# Altium schematic sheet Э3("All Documents",Physical)
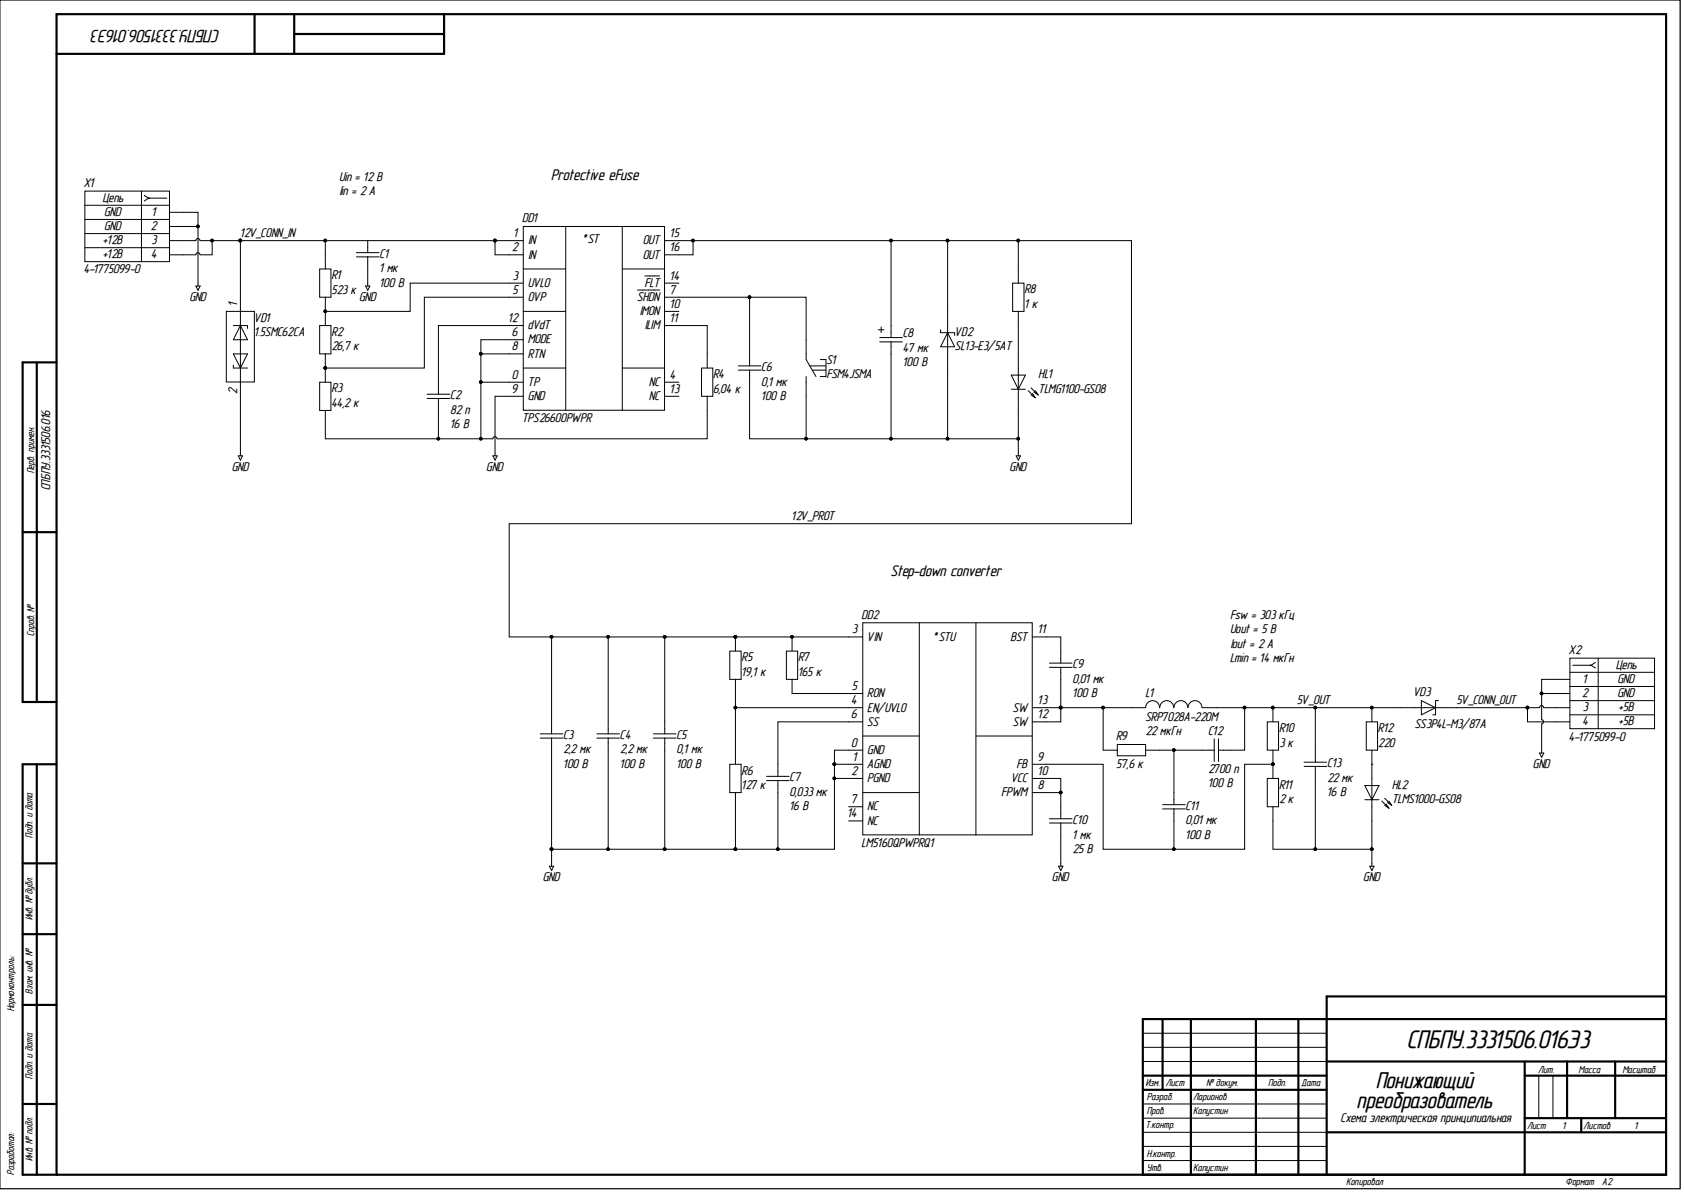
[diagram p0: Э3("All Documents",Physical)]

## Parts
C1  1 мк  Номинальное напряжение: 100 В  [C1206]
C2  82 п  Номинальное напряжение: 16 В  [C0603]
C3  2,2 мк  [C1206]
C4  2,2 мк  Номинальное напряжение: 100 В  [C1206]
C5  0,1 мк  Номинальное напряжение: 100 В  [C0603]
C6  0,1 мк  [C0603]
C7  0,033 мк  Номинальное напряжение: 16 В  [C0603]
C8  47 мк  [CAPAE-1050X1050X1250]
C9  0,01 мк  Номинальное напряжение: 100 В  [C0603]
C10  1 мк  Номинальное напряжение: 25 В  [C0603]
C11  0,01 мк  Номинальное напряжение: 100 В  [C0603]
C12  2700 п  Номинальное напряжение: 100 В  [C0603]
C13  22 мк  Номинальное напряжение: 16 В  [C1206]
DD1  TPS26600PWPR  [HTSSOP-16]
DD2  LM5160QPWPRQ1  [HTSSOP-14]
HL1  TLMG1100-GS08  [HL0603]
HL2  TLMS1000-GS08  [HL0603]
L1  SRP7028A-220M  [SRP-2-670X660X280]
R1  523 к  [R0603]
R2  26,7 к  [R0603]
R3  44,2 к  [R0603]
R4  6,04 к  [R0603]
R5  19,1 к  [R0603]
R6  127 к  [R0603]
R7  165 к  [R0603]
R8  1 к  [R1218]
R9  57,6 к  [R0603]
R10  3 к  [R0603]
R11  2 к  [R0603]
R12  220  [R0603]
S1  FSM4JSMA  [FSM4JSMA]
VD1  1.5SMC62CA  [DO-214AB]
VD2  SL13-E3/5AT  [DO-214AC]
VD3  SS3P4L-M3/87A  [TO-277A]
X1  4-1775099-0  Contact_4: +12В  Contact_2: GND  [4-1775099-0]
X2  4-1775099-0  Contact_4: +5В  Contact_2: GND  [4-1775099-0]

## Nets
GND: C1.2 C3.2 C4.2 C5.2 C6.2 C7.2 C8.2 C10.2 C13.2 DD1.9(GND) DD2.0(GND) DD2.1(AGND) DD2.2(PGND) HL1.1 HL2.1 R6.1 R11.1 S1.2 VD1.2 VD2.2 X1.1 X1.2 X2.1 X2.2
5V_CONN_OUT: VD3.1 X2.3 X2.4
5V_OUT: C12.1 C13.1 L1.2 R10.2 R12.2 VD3.2
12V_CONN_IN: C1.1 DD1.1(IN) DD1.2(IN) R1.2 VD1.1 X1.3 X1.4
12V_PROT: C3.1 C4.1 C5.1 C8.1 DD1.15(OUT) DD1.16(OUT) DD2.3(VIN) R5.2 R7.2 R8.2 VD2.1
NetC2_1: C2.1 DD1.12(dVdT)
NetC2_2: C2.2 DD1.0(TP) DD1.6(MODE) DD1.8(RTN) R3.1 R4.1
NetC6_1: C6.1 DD1.7(SHDN) S1.1
NetC7_1: C7.1 DD2.6(SS)
NetC9_1: C9.1 DD2.11(BST)
NetC9_2: C9.2 DD2.12(SW) DD2.13(SW) L1.1 R9.1
NetC10_1: C10.1 DD2.8(FPWM) DD2.10(VCC)
NetC11_1: C11.1 C12.2 R9.2
NetC11_2: C11.2 DD2.9(FB) R10.1 R11.2
NetDD1_3: DD1.3(UVLO) R1.1 R2.2
NetDD1_4: DD1.4(NC)
NetDD1_5: DD1.5(OVP) R2.1 R3.2
NetDD1_10: DD1.10(IMON)
NetDD1_11: DD1.11(ILIM) R4.2
NetDD1_13: DD1.13(NC)
NetDD1_14: DD1.14(FLT)
NetDD2_4: DD2.4(EN/UVLO) R5.1 R6.2
NetDD2_5: DD2.5(RON) R7.1
NetDD2_7: DD2.7(NC)
NetDD2_14: DD2.14(NC)
NetHL1_2: HL1.2 R8.1
NetHL2_2: HL2.2 R12.1

## Library data of the parts
C1 = c0019: =Описание; Фамилия: Ларионов; Рабочие температуры: от -55 °С до +125 °С; Производитель: YAGEO Corp.; Отклонение: ±10 %; Описание: Конденсатор чип 1206 1 мкФ 100 В ±10 %; Наименование: CC1206KKX7R0BB105; Корпус: 1206; Database Table Name: Конденсаторы$; Номинал: 1 мк; Напряжение: 100 В; ID: c0019; HelpURL: https://www.yageo.com/upload/media/product/productsearch/datasheet/mlcc/UPY-NP0X7R_MV_100-to-630V_22.pdf; Description: Конденсатор чип 1206 1 мкФ 100 В ±10 %; Component Kind: Standard; Library Name: Database_Students.DbLib
C2 = c0010: =Описание; Фамилия: Ларионов; Рабочие температуры: от -55 °С до +125 °С; Производитель: YAGEO Corp.; Отклонение: ±5 %; Описание: Конденсатор чип 0603 82 пФ 16 В ±5 %; Наименование: CC0603JRNPO7BN820; Корпус: 0603; Database Table Name: Конденсаторы$; Номинал: 82 п; Напряжение: 16 В; ID: c0010; HelpURL: https://www.yageo.com/upload/media/product/productsearch/datasheet/mlcc/UPY-GP_NP0_16V-to-50V_18.pdf; Description: Конденсатор чип 0603 82 пФ 16 В ±5 %; Component Kind: Standard; Library Name: Database_Students.DbLib
C3 = c0022: =Описание; Фамилия: Ларионов; Рабочие температуры: от -55 °С до +125 °С; Производитель: YAGEO Corp.; Отклонение: ±10 %; Описание: Конденсатор чип 1206 2,2 мкФ 100 В ±10 %; Номинальное напряжение: 100 В; Наименование: CC1206KKX7R0BB225; Корпус: 1206; Database Table Name: Конденсаторы$; Номинал: 2,2 мк; Напряжение: 100 В; ID: c0022; HelpURL: https://www.yageo.com/upload/media/product/productsearch/datasheet/mlcc/UPY-NP0X7R_MV_100-to-630V_22.pdf; Description: Конденсатор чип 1206 2,2 мкФ 100 В ±10 %; Component Kind: Standard; Library Name: Database_Students.DbLib
C4 = c0022: =Описание; Фамилия: Ларионов; Рабочие температуры: от -55 °С до +125 °С; Производитель: YAGEO Corp.; Отклонение: ±10 %; Описание: Конденсатор чип 1206 2,2 мкФ 100 В ±10 %; Наименование: CC1206KKX7R0BB225; Корпус: 1206; Database Table Name: Конденсаторы$; Номинал: 2,2 мк; Напряжение: 100 В; ID: c0022; HelpURL: https://www.yageo.com/upload/media/product/productsearch/datasheet/mlcc/UPY-NP0X7R_MV_100-to-630V_22.pdf; Description: Конденсатор чип 1206 2,2 мкФ 100 В ±10 %; Component Kind: Standard; Library Name: Database_Students.DbLib
C5 = c0015: =Описание; Фамилия: Ларионов; Рабочие температуры: от -55 °С до +125 °С; Производитель: YAGEO Corp.; Отклонение: ±10 %; Описание: Конденсатор чип 0603 0,1 мкФ 100 В ±10 %; Наименование: CC0603KRX7R0BB104; Корпус: 0603; Database Table Name: Конденсаторы$; Номинал: 0,1 мк; Напряжение: 100 В; ID: c0015; HelpURL: https://www.yageo.com/upload/media/product/productsearch/datasheet/mlcc/UPY-NP0X7R_MV_100-to-630V_22.pdf; Description: Конденсатор чип 0603 0,1 мкФ 100 В ±10 %; Component Kind: Standard; Library Name: Database_Students.DbLib
C6 = c0015: =Описание; Фамилия: Ларионов; Рабочие температуры: от -55 °С до +125 °С; Производитель: YAGEO Corp.; Отклонение: ±10 %; Описание: Конденсатор чип 0603 0,1 мкФ 100 В ±10 %; Номинальное напряжение: 100 В; Наименование: CC0603KRX7R0BB104; Корпус: 0603; Database Table Name: Конденсаторы$; Номинал: 0,1 мк; Напряжение: 100 В; ID: c0015; HelpURL: https://www.yageo.com/upload/media/product/productsearch/datasheet/mlcc/UPY-NP0X7R_MV_100-to-630V_22.pdf; Description: Конденсатор чип 0603 0,1 мкФ 100 В ±10 %; Component Kind: Standard; Library Name: Database_Students.DbLib
C7 = c0013: =Описание; Фамилия: Ларионов; Рабочие температуры: от -55 °С до +125 °С; Производитель: YAGEO Corp.; Отклонение: ±5 %; Описание: Конденсатор чип 0603 0,033 мкФ 16 В ±5 %; Наименование: CC0603JPX7R7BB333; Корпус: 0603; Database Table Name: Конденсаторы$; Номинал: 0,033 мк; Напряжение: 16 В; ID: c0013; HelpURL: https://www.yageo.com/upload/media/product/productsearch/datasheet/mlcc/UPY-GPHC_X7R_6.3V-to-50V_20.pdf; Description: Конденсатор чип 0603 0,033 мкФ 16 В ±5 %; Component Kind: Standard; Library Name: Database_Students.DbLib
C8 = c0030: =Описание; Фамилия: Ларионов; Рабочие температуры: от -55 °С до +125 °С; Производитель: Vishay Intertechnology, Inc.; Отклонение: ±20 %; Описание: Конденсатор электролитический 47 мкФ 100 В ±20 %; Номинальное напряжение: 100 В; Наименование: MAL214699904E3; Корпус: CAPAE (10,5 x 10,5 x 12,5); Database Table Name: Конденсаторы$; Номинал: 47 мк; Напряжение: 100 В; ID: c0030; HelpURL: https://www.vishay.com/capacitors/list/product-28403/; Description: Конденсатор электролитический 47 мкФ 100 В ±20 %; Component Kind: Standard; Library Name: Database_Students.DbLib
C9 C11 = c0012: =Описание; Фамилия: Ларионов; Рабочие температуры: от -55 °С до +125 °С; Производитель: YAGEO Corp.; Отклонение: ±5 %; Описание: Конденсатор чип 0603 0,01 мкФ 100 В ±5 %; Наименование: CC0603JRX7R0BB103; Корпус: 0603; Database Table Name: Конденсаторы$; Номинал: 0,01 мк; Напряжение: 100 В; ID: c0012; HelpURL: https://www.yageo.com/upload/media/product/productsearch/datasheet/mlcc/UPY-NP0X7R_MV_100-to-630V_22.pdf; Description: Конденсатор чип 0603 0,01 мкФ 100 В ±5 %; Component Kind: Standard; Library Name: Database_Students.DbLib
C10 = c0017: =Описание; Фамилия: Ларионов; Рабочие температуры: от -55 °С до +125 °С; Производитель: YAGEO Corp.; Отклонение: ±10 %; Описание: Конденсатор чип 0603 1 мкФ 25 В ±10 %; Наименование: CC0603KRX7R8BB105; Корпус: 0603; Database Table Name: Конденсаторы$; Номинал: 1 мк; Напряжение: 25 В; ID: c0017; HelpURL: https://www.yageo.com/upload/media/product/products/datasheet/mlcc/UPY-GPHC_X7R_6.3V-to-50V_20.pdf; Description: Конденсатор чип 0603 1 мкФ 25 В ±10 %; Component Kind: Standard; Library Name: Database_Students.DbLib
C12 = c0011: =Описание; Фамилия: Ларионов; Рабочие температуры: от -55 °С до +125 °С; Производитель: YAGEO Corp.; Отклонение: ±10 %; Описание: Конденсатор чип 0603 2700 пФ 100 В ±10 %; Наименование: CC0603KRX7R0BB272; Корпус: 0603; Database Table Name: Конденсаторы$; Номинал: 2700 п; Напряжение: 100 В; ID: c0011; HelpURL: https://www.yageo.com/upload/media/product/productsearch/datasheet/mlcc/UPY-NP0X7R_MV_100-to-630V_22.pdf; Description: Конденсатор чип 0603 2700 пФ 100 В ±10 %; Component Kind: Standard; Library Name: Database_Students.DbLib
C13 = c0026: =Описание; Фамилия: Ларионов; Рабочие температуры: от -55 °С до +125 °С; Производитель: YAGEO Corp.; Отклонение: ±20 %; Описание: Конденсатор чип 1206 22 мкФ 16 В ±20 %; Наименование: CC1206MKX7R7BB226; Корпус: 1206; Database Table Name: Конденсаторы$; Номинал: 22 мк; Напряжение: 16 В; ID: c0026; HelpURL: https://www.yageo.com/upload/media/product/productsearch/datasheet/mlcc/UPY-GPHC_X7R_6.3V-to-50V_20.pdf; Description: Конденсатор чип 1206 22 мкФ 16 В ±20 %; Component Kind: Standard; Library Name: Database_Students.DbLib
DD1 = dd0001: =Описание; Фамилия: Ларионов; Ток: от 0,1 А до 2,23 А; Рабочие температуры: от -40 °С до +125 °С; Производитель: Texas Instruments; Описание: Защитная микросхема eFuse 60 В и 2 А; Напряжение: от 4,2 В до 60 В; Максимальное напряжение: 62 В; Database Table Name: Защитные микросхемы$; Наименование: TPS26600PWPR; Корпус: HTSSOP-16; ID: dd0001; HelpURL: https://www.ti.com/product/TPS2660; Description: Защитная микросхема eFuse 60 В и 2 А; Component Kind: Standard; Library Name: Database_Students.DbLib
DD2 = dd0003: =Описание; Частота переключения: до 1 МГц; Фамилия: Ларионов; Рабочие температуры: от -40 °С до +125 °С; Производитель: Texas Instruments; Описание: Понижающий преобразователь напряжения 65 В и 2 А; Коммутируемый ток: до 2 А; Выходное напряжение: не указано; Входное напряжение: от 4,5 В до 65 В; Database Table Name: Преобразователи напряжения$; Наименование: LM5160QPWPRQ1; Корпус: HTSSOP-14; ID: dd0003; HelpURL: https://www.ti.com/product/LM5160-Q1; Description: Понижающий преобразователь напряжения 65 В и 2 А; Component Kind: Standard; Library Name: Database_Students.DbLib
HL1 = hl0005: =Описание; Цвет: Green; Фамилия: Ларионов; Рассеиваемая мощность: 90 мВт; Рабочие температуры: от -40 °С до +100 °С; Производитель: Vishay Intertechnology, Inc.; Описание: Светодиод чип 0603 зеленый; Номинальный прямой ток: 30 мА; Номинальное падение напряжения: 2,1 В; Номинальная сила света: 35 мкд; Номинальная длина волны: 570 нм; Корпус: 0603; Database Table Name: Светодиоды$; Наименование: TLMG1100-GS08; ID: hl0005; HelpURL: https://www.vishay.com/leds/list/product-83173/; Description: Светодиод чип 0603 зеленый; Component Kind: Standard; Library Name: Database_Students.DbLib
HL2 = hl0001: =Описание; Цвет: Red; Фамилия: Ларионов; Рассеиваемая мощность: 40 мВт; Рабочие температуры: от -40 °С до +100 °С; Производитель: Vishay Intertechnology, Inc.; Описание: Светодиод чип 0603 красный; Номинальный прямой ток: 15 мА; Номинальное падение напряжения: 1,8 В; Номинальная сила света: 4 мкд; Номинальная длина волны: 628 нм; Корпус: 0603; Database Table Name: Светодиоды$; Наименование: TLMS1000-GS08; ID: hl0001; HelpURL: https://www.vishay.com/leds/list/product-83172/; Description: Светодиод чип 0603 красный; Component Kind: Standard; Library Name: Database_Students.DbLib
L1 = l0002: =Описание; Частота резонанса: 10 МГц; Фамилия: Ларионов; Ток насыщения: 3,5 А; Рабочие температуры: от -55 °С до +150 °С; Рабочее напряжение: не указано; Производитель: Bourns, Inc.; Отклонение: ±20 %; Описание: Индуктивность 22 мкГн ±20 %; Номинальный ток (при нагреве на 20 °С/40 °С): 2 А; Номинальное активное сопротивление: 165 мОм; Номинал: 22 мкГн; Максимальное активное сопротивление: 190 мОм; Корпус: 6,7 x 6,6 x 2,8; Database Table Name: Индуктивности$; Наименование: SRP7028A-220M; Индуктивность: 22 мкГн; ID: l0002; HelpURL: https://www.bourns.com/products/magnetic-products/power-inductors-aec-q200-compliant/product/SRP7028A; Description: Индуктивность 22 мкГн ±20 %; Component Kind: Standard; Library Name: Database_Students.DbLib
R1 = r0032: =Описание; Фамилия: Жернаков; Рабочие температуры: от -55 °С до +155 °С; Производитель: YAGEO Corp.; Отклонение: ±1 %; Описание: Резистор чип 0603 523 кОм ±1 % 0,1 Вт; Номинальный ток: 1 А; Номинальное напряжение: 75 В; Наименование: RC0603FR-07523KL; Корпус: 0603; Database Table Name: Резисторы$; Номинал: 523 к; ID: r0032; HelpURL: https://www.yageo.com/upload/media/product/productsearch/datasheet/rchip/PYu-RC_Group_51_RoHS_L_11.pdf; Description: Резистор чип 0603 523 кОм ±1 % 0,1 Вт; Component Kind: Standard; Library Name: Database_Students.DbLib
R2 = r0022: =Описание; Фамилия: Ларионов; Рабочие температуры: от -55 °С до +155 °С; Производитель: YAGEO Corp.; Отклонение: ±1 %; Описание: Резистор чип 0603 26,7 кОм ±1 % 0,1 Вт; Номинальный ток: 1 А; Номинальное напряжение: 75 В; Наименование: RC0603FR-0726K7L; Корпус: 0603; Database Table Name: Резисторы$; Номинал: 26,7 к; ID: r0022; HelpURL: https://www.yageo.com/upload/media/product/productsearch/datasheet/rchip/PYu-RC_Group_51_RoHS_L_11.pdf; Description: Резистор чип 0603 26,7 кОм ±1 % 0,1 Вт; Component Kind: Standard; Library Name: Database_Students.DbLib
R3 = r0023: =Описание; Фамилия: Ларионов; Рабочие температуры: от -55 °С до +155 °С; Производитель: YAGEO Corp.; Отклонение: ±1 %; Описание: Резистор чип 0603 44,2 кОм ±1 % 0,1 Вт; Номинальный ток: 1 А; Номинальное напряжение: 75 В; Наименование: RC0603FR-0744K2L; Корпус: 0603; Database Table Name: Резисторы$; Номинал: 44,2 к; ID: r0023; HelpURL: https://www.yageo.com/upload/media/product/productsearch/datasheet/rchip/PYu-RC_Group_51_RoHS_L_11.pdf; Description: Резистор чип 0603 44,2 кОм ±1 % 0,1 Вт; Component Kind: Standard; Library Name: Database_Students.DbLib
R4 = r0015: =Описание; Фамилия: Ларионов; Рабочие температуры: от -55 °С до +155 °С; Производитель: YAGEO Corp.; Отклонение: ±1 %; Описание: Резистор чип 0603 6,04 кОм ±1 % 0,1 Вт; Номинальный ток: 1 А; Номинальное напряжение: 75 В; Наименование: RC0603FR-076K04L; Корпус: 0603; Database Table Name: Резисторы$; Номинал: 6,04 к; ID: r0015; HelpURL: https://www.yageo.com/upload/media/product/productsearch/datasheet/rchip/PYu-RC_Group_51_RoHS_L_11.pdf; Description: Резистор чип 0603 6,04 кОм ±1 % 0,1 Вт; Component Kind: Standard; Library Name: Database_Students.DbLib
R5 = r0020: =Описание; Фамилия: Ларионов; Рабочие температуры: от -55 °С до +155 °С; Производитель: YAGEO Corp.; Отклонение: ±1 %; Описание: Резистор чип 0603 19,1 кОм ±1 % 0,1 Вт; Номинальный ток: 1 А; Номинальное напряжение: 75 В; Наименование: RC0603FR-0719K1L; Корпус: 0603; Database Table Name: Резисторы$; Номинал: 19,1 к; ID: r0020; HelpURL: https://www.yageo.com/upload/media/product/productsearch/datasheet/rchip/PYu-RC_Group_51_RoHS_L_11.pdf; Description: Резистор чип 0603 19,1 кОм ±1 % 0,1 Вт; Component Kind: Standard; Library Name: Database_Students.DbLib
R6 = r0028: =Описание; Фамилия: Ларионов; Рабочие температуры: от -55 °С до +155 °С; Производитель: YAGEO Corp.; Отклонение: ±1 %; Описание: Резистор чип 0603 127 кОм ±1 % 0,1 Вт; Номинальный ток: 1 А; Номинальное напряжение: 75 В; Наименование: RC0603FR-07127KL; Корпус: 0603; Database Table Name: Резисторы$; Номинал: 127 к; ID: r0028; HelpURL: https://www.yageo.com/upload/media/product/productsearch/datasheet/rchip/PYu-RC_Group_51_RoHS_L_11.pdf; Description: Резистор чип 0603 127 кОм ±1 % 0,1 Вт; Component Kind: Standard; Library Name: Database_Students.DbLib
R7 = r0030: =Описание; Фамилия: Ларионов; Рабочие температуры: от -55 °С до +155 °С; Производитель: YAGEO Corp.; Отклонение: ±1 %; Описание: Резистор чип 0603 165 кОм ±1 % 0,1 Вт; Номинальный ток: 1 А; Номинальное напряжение: 75 В; Наименование: RC0603FR-07165KL; Корпус: 0603; Database Table Name: Резисторы$; Номинал: 165 к; ID: r0030; HelpURL: https://www.yageo.com/upload/media/product/productsearch/datasheet/rchip/PYu-RC_Group_51_RoHS_L_11.pdf; Description: Резистор чип 0603 165 кОм ±1 % 0,1 Вт; Component Kind: Standard; Library Name: Database_Students.DbLib
R8 = r0012: =Описание; Фамилия: Ларионов; Рабочие температуры: от -55 °С до +155 °С; Производитель: YAGEO Corp.; Отклонение: ±1 %; Описание: Резистор чип 1218 1 кОм ±1 % 1 Вт; Номинальный ток: 6 А; Номинальное напряжение: 200 В; Наименование: AA1218FK-071KL; Корпус: 1218; Database Table Name: Резисторы$; Номинал: 1 к; ID: r0012; HelpURL: https://www.yageo.com/upload/media/product/productsearch/datasheet/rchip/PYu-AA_51_RoHS_L_3.pdf; Description: Резистор чип 1218 1 кОм ±1 % 1 Вт; Component Kind: Standard; Library Name: Database_Students.DbLib
R9 = r0025: =Описание; Фамилия: Ларионов; Рабочие температуры: от -55 °С до +155 °С; Производитель: YAGEO Corp.; Отклонение: ±1 %; Описание: Резистор чип 0603 57,6 кОм ±1 % 0,1 Вт; Номинальный ток: 1 А; Номинальное напряжение: 75 В; Наименование: RC0603FR-0757K6L; Корпус: 0603; Database Table Name: Резисторы$; Номинал: 57,6 к; ID: r0025; HelpURL: https://www.yageo.com/upload/media/product/productsearch/datasheet/rchip/PYu-RC_Group_51_RoHS_L_11.pdf; Description: Резистор чип 0603 57,6 кОм ±1 % 0,1 Вт; Component Kind: Standard; Library Name: Database_Students.DbLib
R10 = r0013: =Описание; Фамилия: Ларионов; Рабочие температуры: от -55 °С до +155 °С; Производитель: YAGEO Corp.; Отклонение: ±1 %; Описание: Резистор чип 0603 3 кОм ±1 % 0,1 Вт; Номинальный ток: 1 А; Номинальное напряжение: 75 В; Наименование: RC0603FR-073KL; Корпус: 0603; Database Table Name: Резисторы$; Номинал: 3 к; ID: r0013; HelpURL: https://www.yageo.com/upload/media/product/productsearch/datasheet/rchip/PYu-RC_Group_51_RoHS_L_11.pdf; Description: Резистор чип 0603 3 кОм ±1 % 0,1 Вт; Component Kind: Standard; Library Name: Database_Students.DbLib
R11 = r0013: =Описание; Фамилия: Ларионов; Рабочие температуры: от -55 °С до +155 °С; Производитель: YAGEO Corp.; Отклонение: ±1 %; Описание: Резистор чип 0603 2 кОм ±1 % 0,1 Вт; Номинальный ток: 1 А; Номинальное напряжение: 75 В; Наименование: RC0603FR-072KL; Корпус: 0603; Database Table Name: Резисторы$; Номинал: 2 к; ID: r0013; HelpURL: https://www.yageo.com/upload/media/product/productsearch/datasheet/rchip/PYu-RC_Group_51_RoHS_L_11.pdf; Description: Резистор чип 0603 2 кОм ±1 % 0,1 Вт; Component Kind: Standard; Library Name: Database_Students.DbLib
R12 = r0009: =Описание; Фамилия: Ларионов; Рабочие температуры: от -55 °С до +155 °С; Производитель: YAGEO Corp.; Отклонение: ±1 %; Описание: Резистор чип 0603 220 Ом ±1 % 0,1 Вт; Номинальный ток: 1 А; Номинальное напряжение: 75 В; Наименование: RC0603FR-07220RL; Корпус: 0603; Database Table Name: Резисторы$; Номинал: 220; ID: r0009; HelpURL: https://www.yageo.com/upload/media/product/productsearch/datasheet/rchip/PYu-RC_Group_51_RoHS_L_11.pdf; Description: Резистор чип 0603 220 Ом ±1 % 0,1 Вт; Component Kind: Standard; Library Name: Database_Students.DbLib
S1 = sw0002: =Описание; Фамилия: Паньков; Ток нагрузки: 50 мА @ 24 В; Сопротивление контакта: 100 мОм; Рабочие температуры: от -35 °С до +85 °С; Промывка: Разрешена; Производитель: TE Connectivity; Описание: Тактовая кнопка поверхностного монтажа; Корпус: 6,00x6,00x4,98; Количество контактов: 1; Database Table Name: Переключатели$; Наименование: FSM4JSMA; ID: sw0002; HelpURL: https://www.te.com/usa-en/product-4-1437565-1.html; Description: Тактовая кнопка поверхностного монтажа; Component Kind: Standard; Library Name: Database_Students.DbLib
VD1 = vd0004: =Описание; Фамилия: Ларионов; Производитель: Littelfuse, Inc.; Описание: Двунаправленная диодная сборка для подавления помех, 53 В; Database Table Name: Диодные сборки$; Наименование: 1.5SMC62CA; Корпус: DO-214AB; ID: vd0004; HelpURL: https://www.littelfuse.com/products/tvs-diodes/surface-mount/1_5smc.aspx; Description: Двунаправленная диодная сборка для подавления помех, 53 В; Component Kind: Standard; Library Name: Database_Students.DbLib
VD2 = vd0001: =Описание; Фамилия: Ларионов; Рабочие температуры: от -55 °С до +125 °С; Производитель: Vishay Intertechnology, Inc.; Пиковое прямое напряжение: 0,445 В; Описание: Диод Шоттки 30 В 1,5 А; Макс допустимый средний прямой ток: 1,5 А; Импульсное обратное напряжение: 30 В; Database Table Name: Диоды$; Наименование: SL13-E3/5AT; Корпус: DO-214AC; ID: vd0001; HelpURL: https://www.vishay.com/product?docid=88740; Description: Диод Шоттки 30 В 1,5 А; Component Kind: Standard; Library Name: Database_Students.DbLib
VD3 = vd0002: =Описание; Фамилия: Ларионов; Рабочие температуры: от -55 °С до +150 °С; Производитель: Vishay Intertechnology, Inc.; Пиковое прямое напряжение: 0,47 В; Описание: Диод Шоттки 40 В 3 А; Макс допустимый средний прямой ток: 3 А; Импульсное обратное напряжение: 40 В; Database Table Name: Диоды$; Наименование: SS3P4L-M3/87A; Корпус: TO-277A; ID: vd0002; HelpURL: https://www.vishay.com/diodes/list/product-88986/; Description: Диод Шоттки 40 В 3 А; Component Kind: Standard; Library Name: Database_Students.DbLib
X1 X2 = x0004: =Описание; Фамилия: Ларионов; Производитель: TE Connectivity; Описание: ATX Power 4 pin гнездо прямой; Database Table Name: Разъемы$; Наименование: 4-1775099-0; ID: x0004; HelpURL: https://www.te.com/usa-en/product-4-1775099-0.html; Description: ATX Power 4 pin гнездо прямой; Contact_1: GND; Component Kind: Standard; Library Name: Database_Students.DbLib
  X1: Contact_3: +12В
  X2: Contact_3: +5В
